# Altium schematic sheet SKC_DataComm.SchDoc(SKC_DataComm)
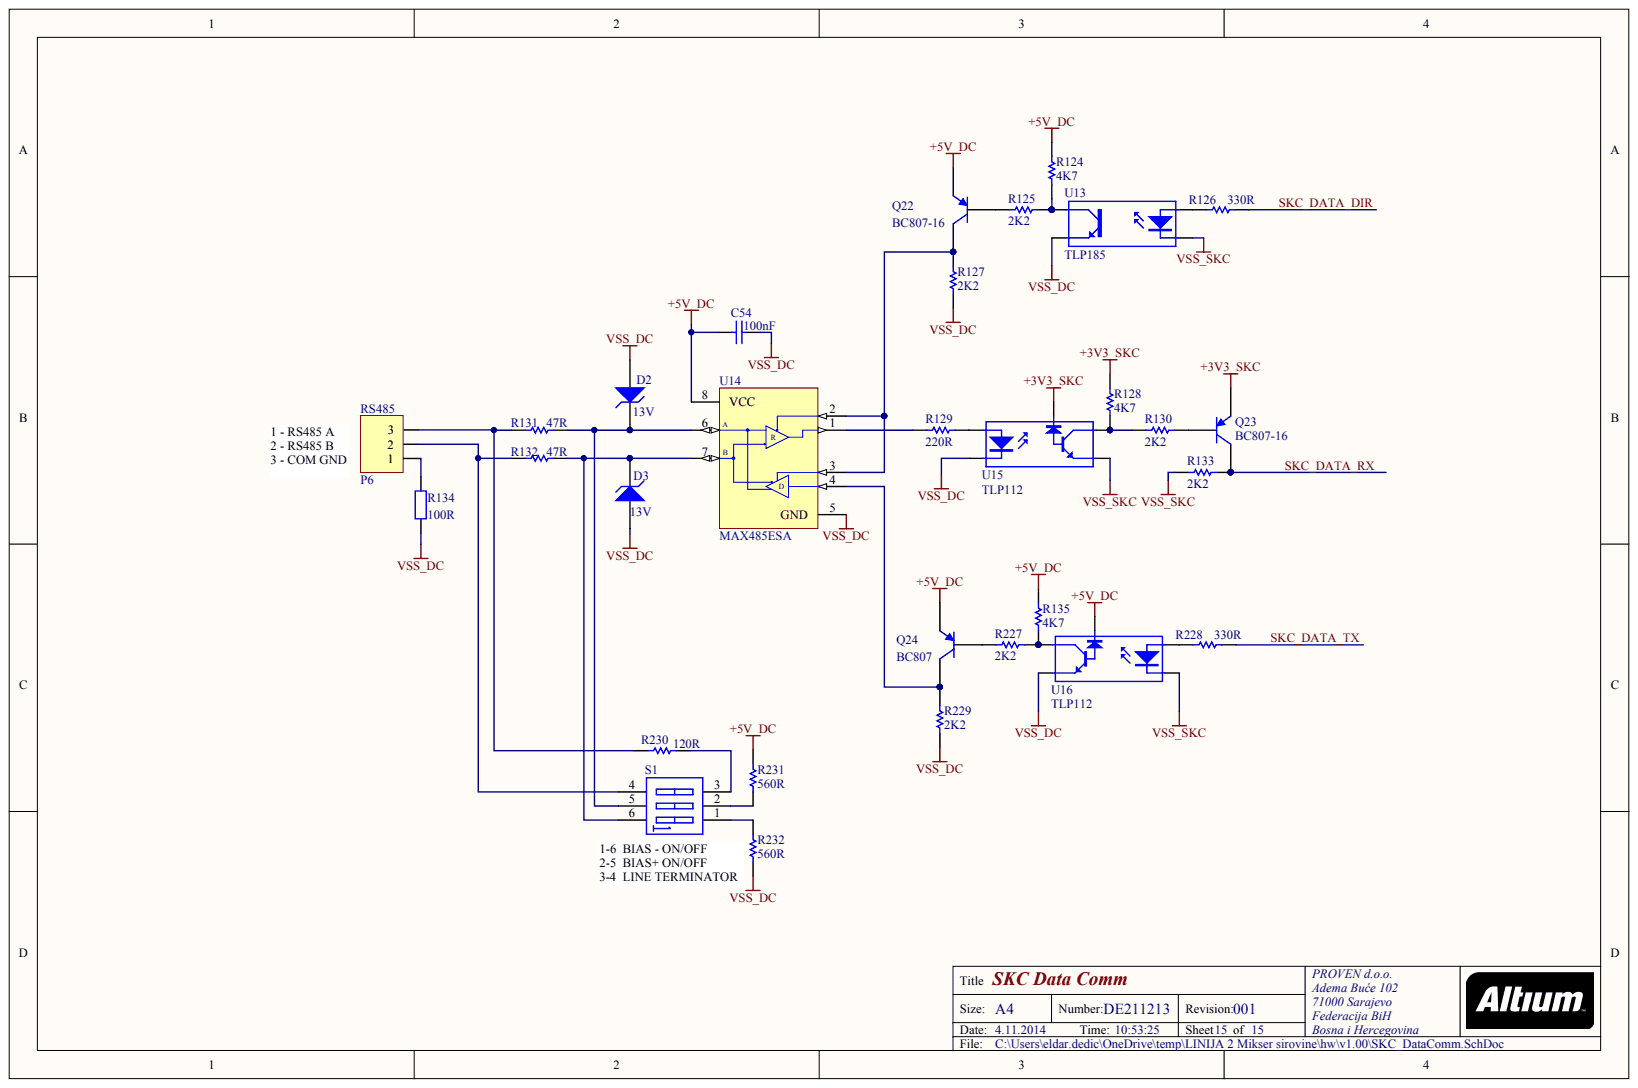
[diagram p0: SKC_DataComm.SchDoc]

## Parts
C54  100nF
D2  13V
D3  13V
P6  RS485
Q22  BC807-16
Q23  BC807-16
Q24  BC807
R124  4K7
R125  2K2
R126  330R
R127  2K2
R128  4K7
R129  220R
R130  2K2
R131  47R
R132  47R
R133  2K2
R134  100R
R135  4K7
R227  2K2
R228  330R
R229  2K2
R230  120R
R231  560R
R232  560R
S1  (no value)
U13  TLP185
U14  MAX485ESA
U15  TLP112
U16  TLP112

## Nets
+3V3_SKC: Q23.2 R128.1 U15.6
+5V_DC: C54.2 Q22.2 Q24.2 R124.1 R135.1 R231.2 U14.8(VCC) U16.6
VSS_DC: C54.1 D2.1 D3.1 R127.1 R134.1 R229.1 R232.1 U13.4 U14.5(GND) U15.3 U16.4
VSS_SKC: R133.1 U13.3 U15.4 U16.3
SKC_DATA_DIR: R126.2
SKC_DATA_RX: Q23.3 R133.2
SKC_DATA_TX: R228.2
NetD2_2: D2.2 R131.2 S1.5 U14.6
NetD3_2: D3.2 R132.2 S1.6 U14.7
NetP6_1: P6.1 R134.2
NetP6_2: P6.2 R132.1 S1.4
NetP6_3: P6.3 R131.1 R230.1
NetQ22_1: Q22.1 R125.2
NetQ22_3: Q22.3 R127.2 U14.2 U14.3
NetQ23_1: Q23.1 R130.1
NetQ24_1: Q24.1 R227.2
NetQ24_3: Q24.3 R229.2 U14.4
NetR124_2: R124.2 R125.1 U13.6
NetR126_1: R126.1 U13.1
NetR128_2: R128.2 R130.2 U15.5
NetR129_1: R129.1 U15.1
NetR129_2: R129.2 U14.1
NetR135_2: R135.2 R227.1 U16.5
NetR228_1: R228.1 U16.1
NetR230_2: R230.2 S1.3
NetR231_1: R231.1 S1.2
NetR232_2: R232.2 S1.1

## Library data of the parts
R124-R133 R135 R227-R232 = (no symbol name): Note: If flow soldering, adjust pad width of PCB Footprint to 0.7 - 0.8 times the width of the resistor.; Published: 17-Dec-2003; LatestRevisionDate: 19-Mar-2004; LatestRevisionNote: Silkscreen size reduced.; PackageDocument: Mar-2003; ComponentLink1Description: Manufacturer Link; ComponentLink1URL: http://www.panasonic.com/industrial/components/resistive_products.htm; ComponentLink2Description: Datasheet; Publisher: Altium Limited
  R124 R125 R128-R132 R135 R230-R232: PackageReference: ERA-0805; Code_IPC: 2012 [0805]; ComponentLink2URL: http://www.panasonic.com/industrial/components/pdf/AOF0000CE1.pdf
  R126 R228: PackageReference: ERA-0805; Code_IPC: 2012 [0805]; ComponentLink2URL: http://www.panasonic.com/industrial/components/pdf/AOA0000CE8.pdf
  R127 R133 R227 R229: PackageReference: 8-1206; Code_IPC: 3216 [1206]; ComponentLink2URL: http://www.panasonic.com/industrial/components/pdf/AOA0000CE1.pdf
C54 D2 D3 P6 Q22-Q24 R134 S1 U13-U16 = (no symbol name)
  C54: Published: 17-Jul-2002; LatestRevisionDate: 19-Mar-2004; LatestRevisionNote: Silkscreen size reduced.; DatasheetDocument: Mar-2001; PackageDocument: Mar-2001; PackageReference: 1206; Code_JEITA: 1206; Code_IPC: 3216 [1206]; ComponentLink1Description: Manufacturer Link; ComponentLink1URL: http://www.kemet.com/; ComponentLink2Description: Datasheet; ComponentLink2URL: http://www.kemet.com/kemet/web/homepage/kechome.nsf/vapubfilesname/F3102TA.pdf/$file/F3102TA.pdf
  D2 D3: Published: 8-Jun-2000; LatestRevisionDate: 17-Jul-2002; LatestRevisionNote: Re-released for DXP Platform.; PackageReference: DIODE-0.7; PackageDescription: Diode, Thru-Hole; 2 Leads; 0.7 in Pin Spacing
  Q22-Q24: Published: 17-Sep-2003; LatestRevisionDate: 12-Dec-2006; LatestRevisionNote: IPC-7351 Footprint Added.; DatasheetVersion: 8-Apr-1999; PackageVersion: May-1999; PackageReference: SOT23; PackageDescription: 3-Pin SOT-23 Package 0.95 mm Pitch, 2.3 mm Lead Span; ComponentLink1Description: Manufacturer Link; ComponentLink1URL: http://www.semiconductors.philips.com/; ComponentLink2Description: Datasheet; ComponentLink2URL: http://www.semiconductors.philips.com/acrobat/datasheets/BC807_BC807W_BC327_5.pdf
  R134: Published: 8-Jun-2000; LatestRevisionDate: 17-Jul-2002; LatestRevisionNote: Re-released for DXP Platform.; PackageReference: AXIAL-0.4; PackageDescription: Axial Device, Thru-Hole; 2 Leads; 0.4 in Pin Spacing
  S1: Published: 24-Mar-1999; LatestRevisionDate: 17-Jul-2002; LatestRevisionNote: Re-released for DXP Platform.; PackageReference: DIP-6; Code_JEDEC: MO-001-AM
  U13 U15 U16: Published: 24-Mar-1999; LatestRevisionDate: 04-Mar-2005; LatestRevisionNote: PCB Footprint replaced, Sch pins re-numbered.; DatasheetVersion: Apr-2004; PackageReference: SOP5(6); PackageDescription: Small Outline; 6 Leads (1 Absent); Body Width 4.4 mm; Pitch 1.27 mm
  U14: Published: 16-Jan-2001; LatestRevisionDate: 13-Apr-2006; LatestRevisionNote: IPC-7351 Footprint Added.; DatasheetVersion: Feb-1996; PackageReference: NSO8; PackageDescription: 8-Pin Small Outline Integrated Circuit 1.27 mm Pitch; Code_JEDEC: MS-012-AA; Code_IPC: SOIC127P600-8; ComponentLink1Description: Manufacturer Link; ComponentLink1URL: http://www.maxim-ic.com/; ComponentLink2Description: Datasheet; ComponentLink2URL: http://pdfserv.maxim-ic.com/en/ds/MAX1487-MAX491.pdf
  C54 D2 D3 Q22-Q24 R134 S1 U13-U16: Publisher: Altium Limited
